# Altium schematic sheet C-03 eMMC_NAND_TF_QSPI.SchDoc("C-03 eMMC_NAND_TF_QSPI")
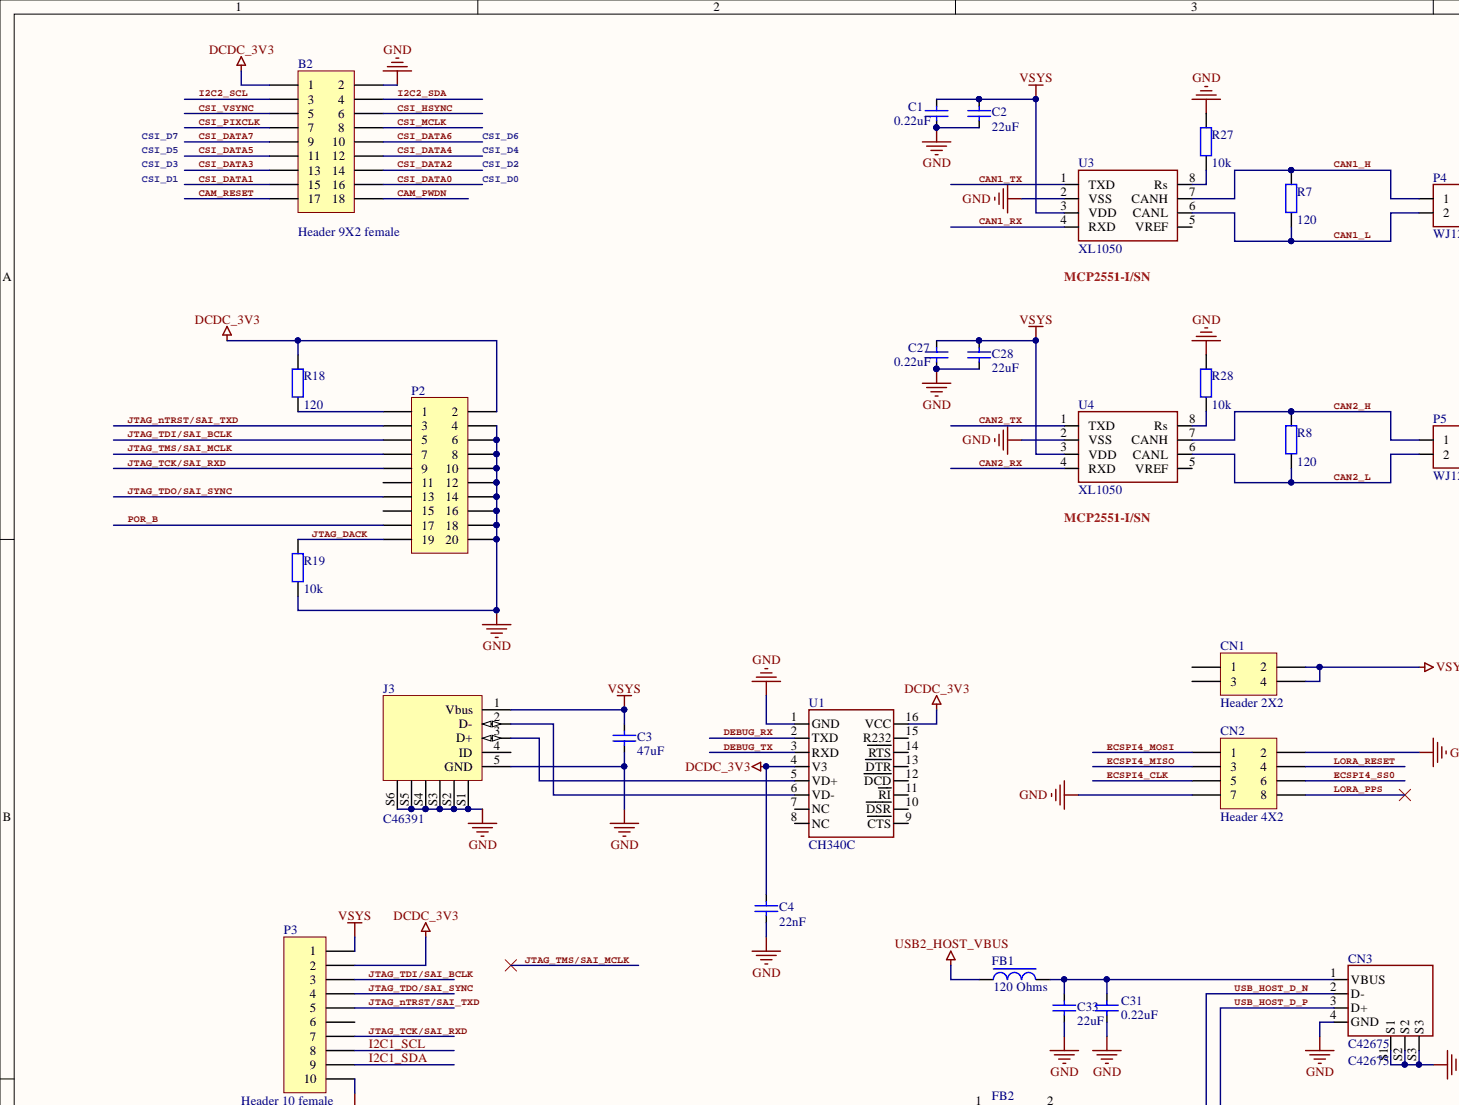
[diagram p0: C-03 eMMC_NAND_TF_QSPI.SchDoc, top left]
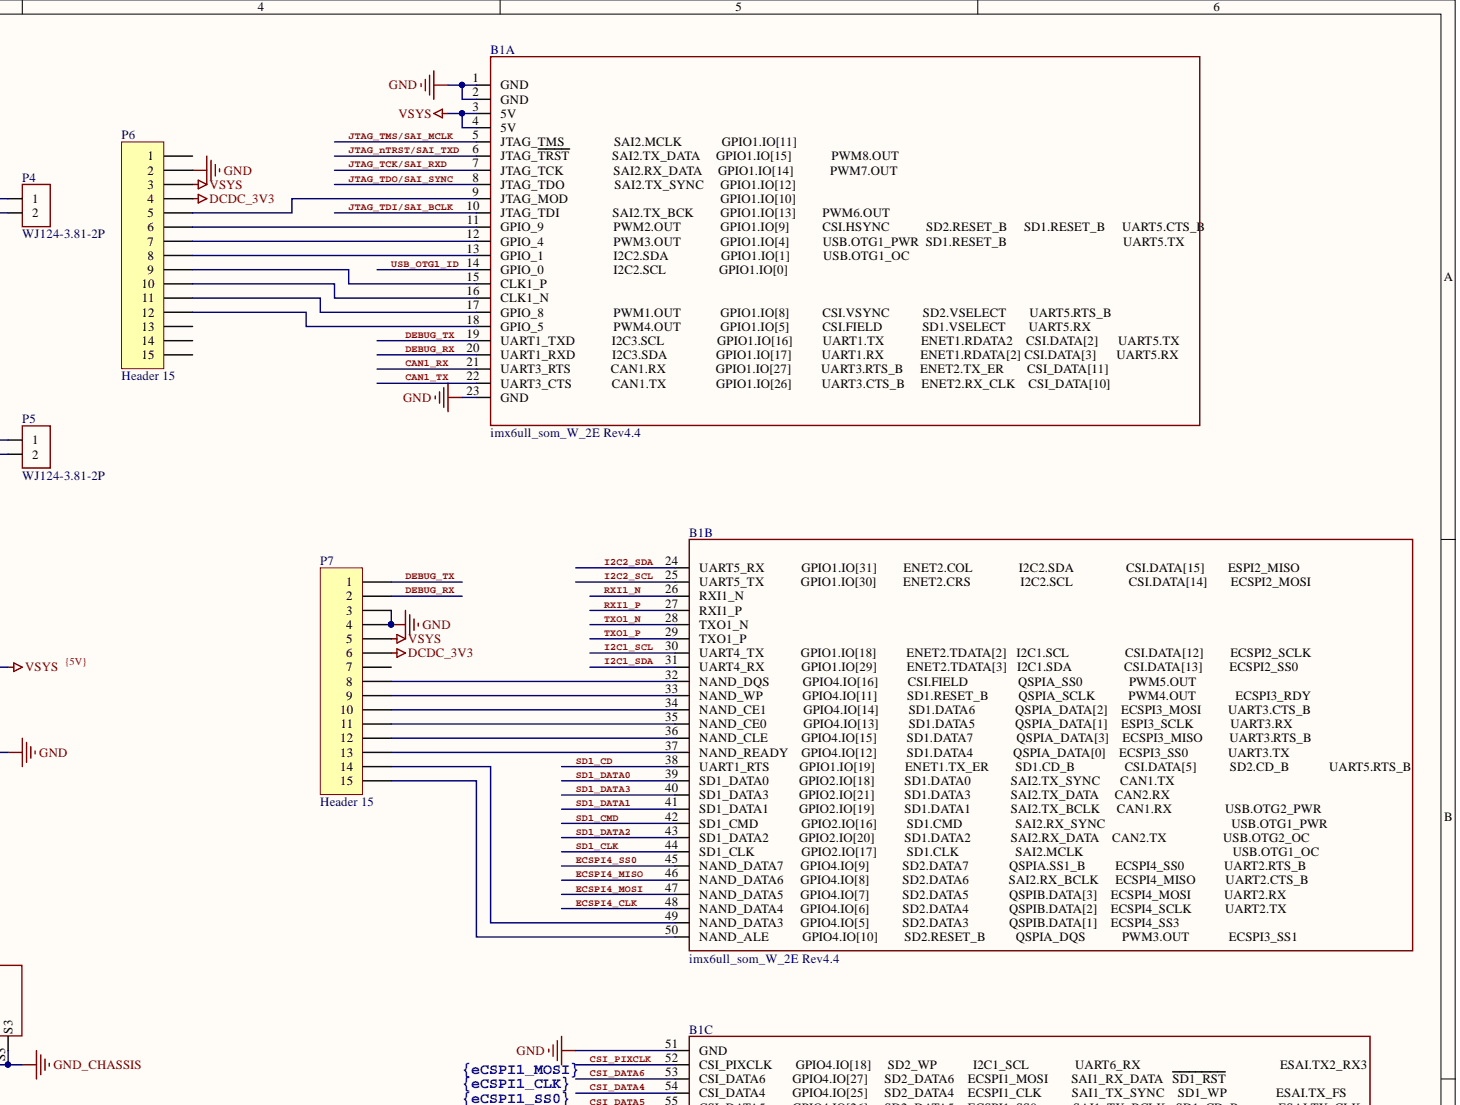
[diagram p1: C-03 eMMC_NAND_TF_QSPI.SchDoc, top right]
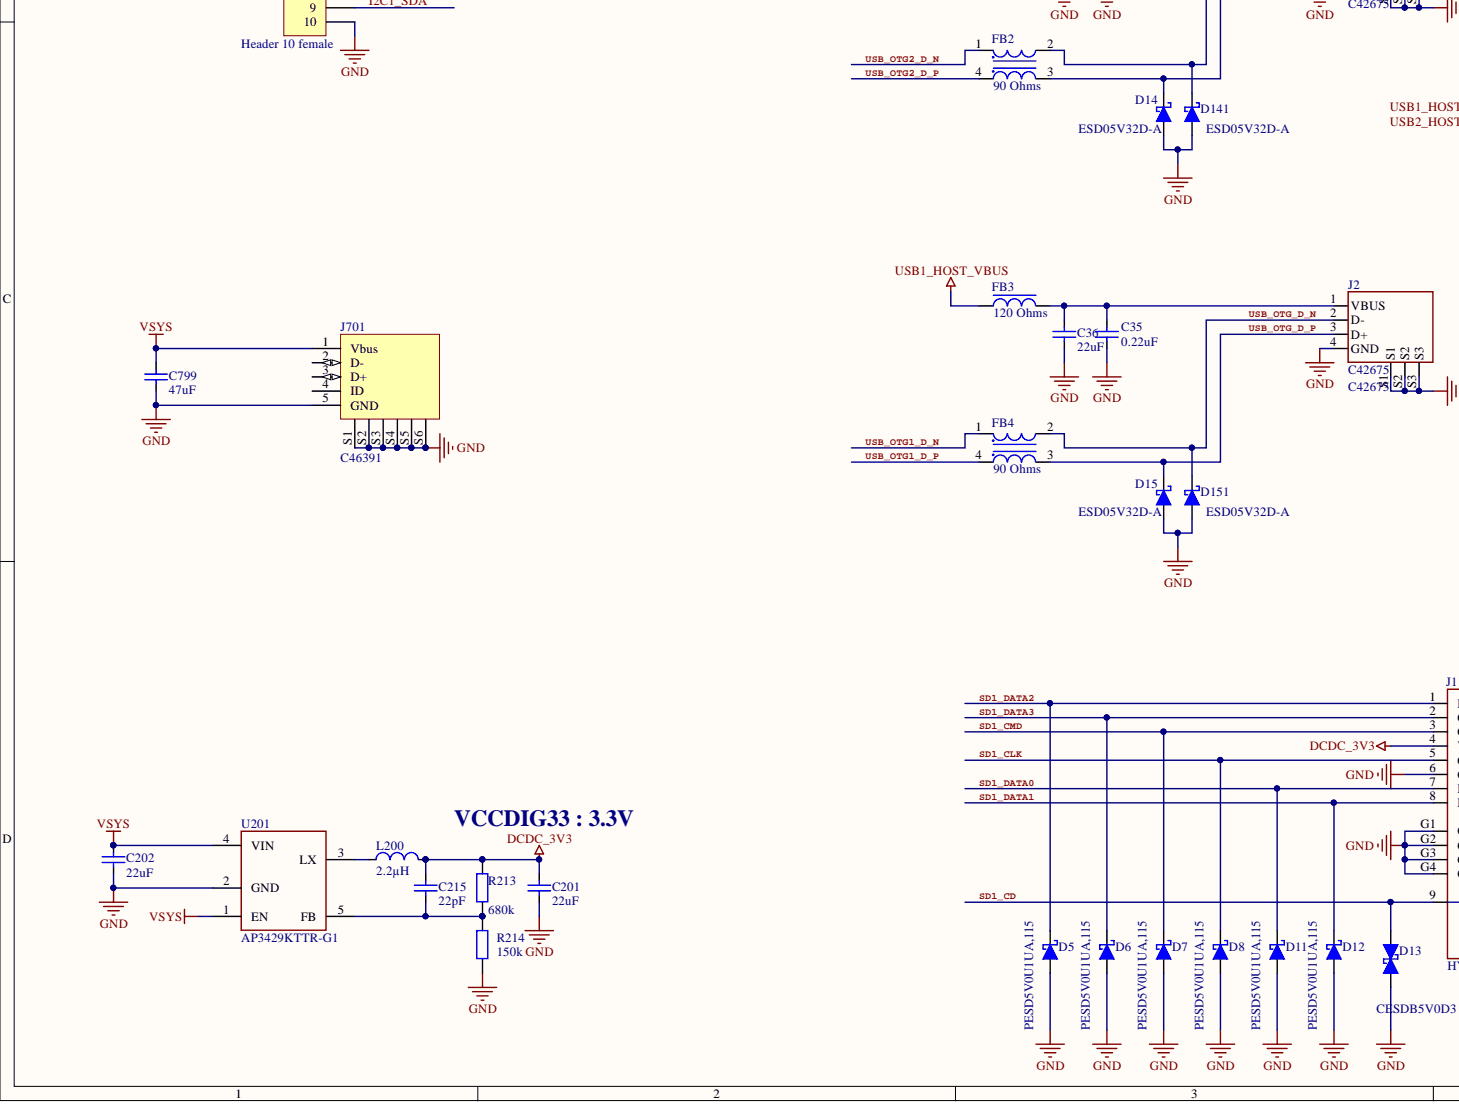
[diagram p2: C-03 eMMC_NAND_TF_QSPI.SchDoc, bottom left]
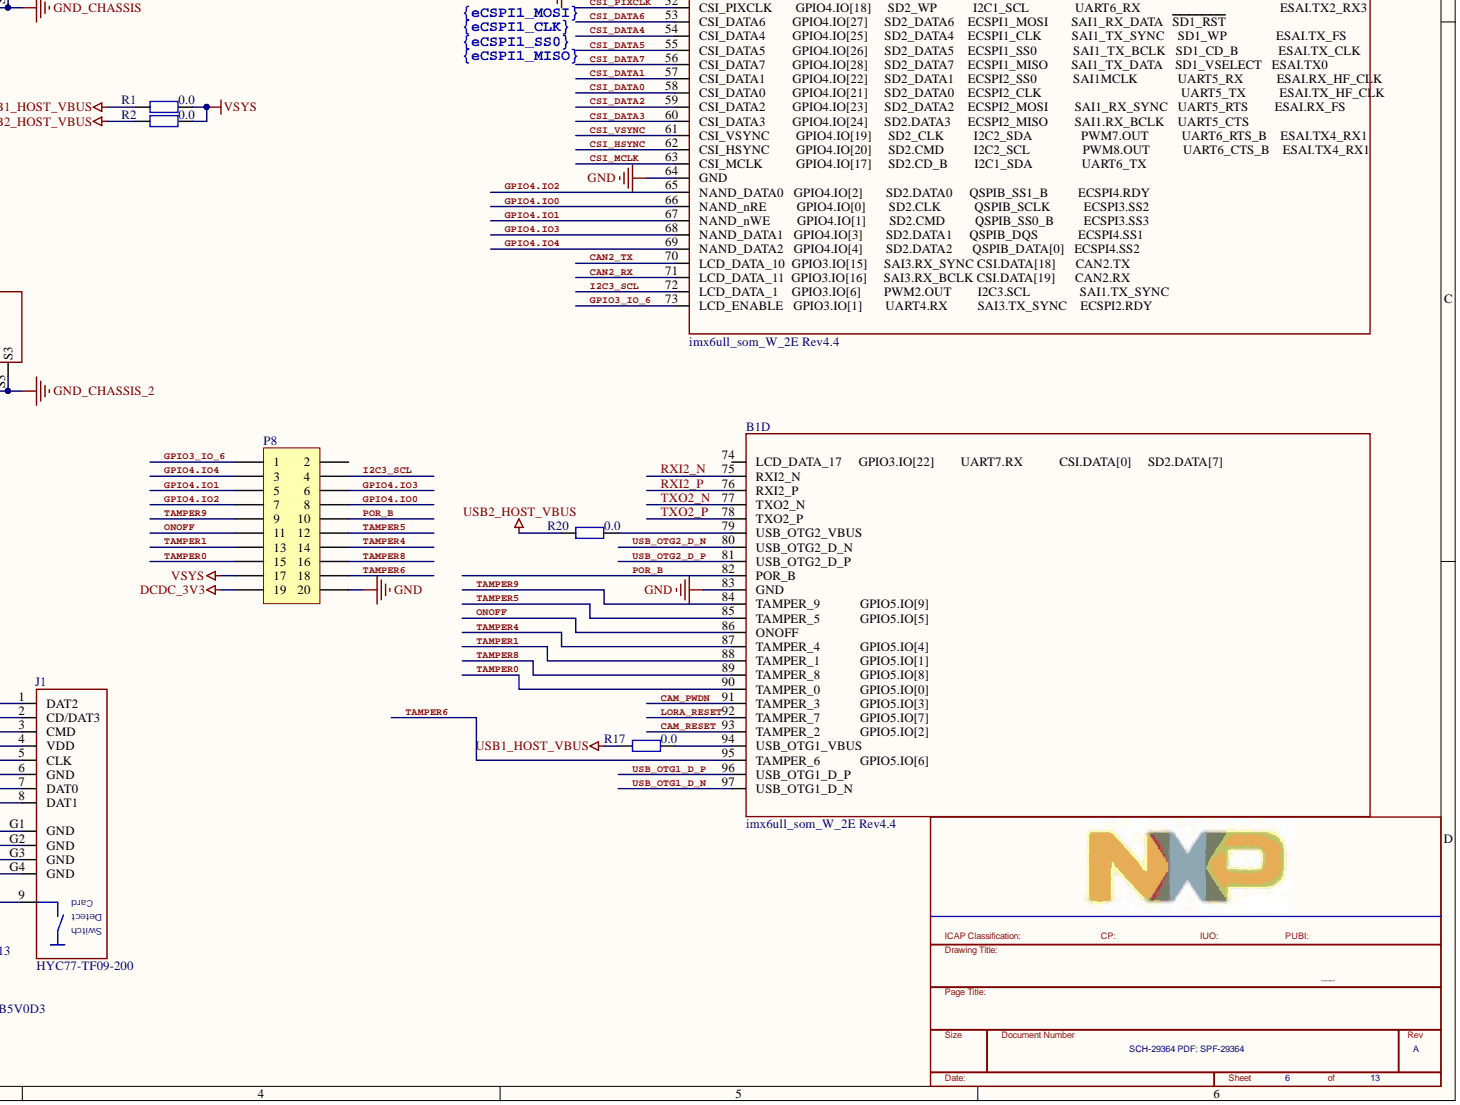
[diagram p3: C-03 eMMC_NAND_TF_QSPI.SchDoc, bottom right]

## Parts
B1  imx6ull_som_W_2E Rev4.4  Component Name: imx6ull_som_W_2E  [imx6ull_som]  (parts A,B,C,D)
B2  Header 9X2 female  Component Name: Header 9X2  [HDR2X9]
C1  value 0.22uF  [CAP0603R]  (hidden comment: CL10B224KA8NNNC)
C2  value 22uF  [CAP1206R]  (hidden comment: CC1206KKX5R6BB226)
C3  value 47uF  [CAP1206R]  (hidden comment: CL31A476MPHNNNE)
C4  value 22nF  [CAP0603R]  (hidden comment: CC0603KRX7R9BB223)
C27  value 0.22uF  [CAP0603R]  (hidden comment: CL10B224KA8NNNC)
C28  value 22uF  [CAP1206R]  (hidden comment: CC1206KKX5R6BB226)
C31  value 0.22uF  [CAP0603R]  (hidden comment: CL10B224KA8NNNC)
C33  value 22uF  [CAP1206R]  (hidden comment: CC1206KKX5R6BB226)
C35  value 0.22uF  [CAP0603R]  (hidden comment: CL10B224KA8NNNC)
C36  value 22uF  [CAP1206R]  (hidden comment: CC1206KKX5R6BB226)
C201  value 22uF  [CAP1206R]  (hidden comment: CC1206KKX5R6BB226)
C202  value 22uF  [CAP1206R]  (hidden comment: CC1206KKX5R6BB226)
C215  value 22pF  [CAP0603R]  (hidden comment: CC0603JRNPO9BN220)
C799  value 47uF  [CAP1206R]  (hidden comment: CL31A476MPHNNNE)
CN1  Header 2X2  [HDR2X2]
CN2  Header 4X2  [HDR2X4]
CN3  C42675  [C2345-Footprint-1]
D5  PESD5V0U1UA,115  [SOD-323]
D6  PESD5V0U1UA,115  [SOD-323]
D7  PESD5V0U1UA,115  [SOD-323]
D8  PESD5V0U1UA,115  [SOD-323]
D11  PESD5V0U1UA,115  [SOD-323]
D12  PESD5V0U1UA,115  [SOD-323]
D13  CESDB5V0D3  [SOD-323]
D14  ESD05V32D-A  [SOD-323]
D15  ESD05V32D-A  [SOD-323]
D141  ESD05V32D-A  [SOD-323]
D151  ESD05V32D-A  [SOD-323]
FB1  Impedance: 120 Ohms  [0603]  (hidden comment: PZ1608U121-2R0TF)
FB2  Impedance: 90 Ohms  [DLW21HN900SQ2L-Footprint-1]  (hidden comment: DLW21HN900SQ2L)
FB3  Impedance: 120 Ohms  [0603]  (hidden comment: PZ1608U121-2R0TF)
FB4  Impedance: 90 Ohms  [DLW21HN900SQ2L-Footprint-1]  (hidden comment: DLW21HN900SQ2L)
J1  HYC77-TF09-200  [0473521001]
J2  C42675  [C2345-Footprint-1]
J3  C46391  [10118192]
J701  C46391  [10118192]
L200  value 2.2µH  [LQH3225]  (hidden comment: LQH32PN2R2NNCL)
P2  CMP-013-0009-2  [HDR2X10]  (value not drawn)
P3  Header 10 female  Component Name: Header 10  [HDR1X10]
P4  WJ124-3.81-2P  [SCREW1X2_3.81mm_pitch]
P5  WJ124-3.81-2P  [SCREW1X2_3.81mm_pitch]
P6  Header 15  [HDR1X15]
P7  Header 15  [HDR1X15]
P8  CMP-013-0009-2  [HDR2X10]  (value not drawn)
R1  value 0.0  [0603]  (hidden comment: CMP-022-0120-1)
R2  value 0.0  [0603]  (hidden comment: CMP-022-0120-1)
R7  value 120  [0603]  (hidden comment: 0603WAF1200T5E)
R8  value 120  [0603]  (hidden comment: 0603WAF1200T5E)
R17  value 0.0  [0603]  (hidden comment: RC0603JR-070RL)
R18  value 120  [0603]  (hidden comment: 0603WAF1200T5E)
R19  value 10k  [0603]  (hidden comment: 0603WAF1002T5E)
R20  value 0.0  [0603]  (hidden comment: CMP-022-0120-1)
R27  value 10k  [0603]  (hidden comment: 0603WAF1002T5E)
R28  value 10k  [0603]  (hidden comment: 0603WAF1002T5E)
R213  value 680k  [0603]  (hidden comment: 0603WAF6803T5E)
R214  value 150k  [0603]  (hidden comment: 0603WAF1503T5E)
U1  CH340C  [SOIC-16_N]
U3  XL1050  [SOIC-8_N]
U4  XL1050  [SOIC-8_N]
U201  AP3429KTTR-G1  [TSOT-23-5]

## Nets
DCDC_3V3: B2.1 C4.2 C201.1 C215.2 J1.4(VDD) L200.2 P2.2 P3.2 P6.4 P7.6 P8.19 R18.2 R213.2 U1.4(V3) U1.16(VCC)
GND: B1.1(GND) B1.2(GND) B1.23(GND) B1.51(GND) B1.64(GND) B1.83(GND) B2.2 C1.1 C2.2 C3.1 C4.1 C27.1 C28.2 C31.1 C33.2 C35.1 C36.2 C201.2 C202.2 C799.1 CN2.2 CN2.7 CN3.4(GND) D5.2 D6.2 D7.2 D8.2 D11.2 D12.2 D13.2 D14.2 D15.2 D141.2 D151.2 J1.6(GND) J1.G1(GND) J1.G2(GND) J1.G3(GND) J1.G4(GND) J2.4(GND) J3.5(GND) J3.S1 J3.S2 J3.S3 J3.S4 J3.S5 J3.S6 J701.5(GND) J701.S1 J701.S2 J701.S3 J701.S4 J701.S5 J701.S6 P2.4 P2.6 P2.8 P2.10 P2.12 P2.14 P2.16 P2.18 P2.20 P3.10 P6.2 P7.3 P7.4 P8.20 R19.1 R27.2 R28.2 R214.1 U1.1(GND) U3.2(VSS) U4.2(VSS) U201.2(GND)
GND_CHASSIS: CN3.S1 CN3.S2 CN3.S3
GND_CHASSIS_2: J2.S1 J2.S2 J2.S3
USB1_HOST_VBUS: FB3.2 R1.1 R17.1
USB2_HOST_VBUS: FB1.2 R2.1 R20.1
VSYS: B1.3(5V) B1.4(5V) C1.2 C2.1 C3.2 C27.2 C28.1 C202.1 C799.2 CN1.2 CN1.4 J3.1(Vbus) J701.1(Vbus) P3.1 P6.3 P7.5 P8.17 R1.2 R2.2 U3.3(VDD) U4.3(VDD) U201.1(EN) U201.4(VIN)
CAM_PWDN: B1.91(TAMPER_3) B2.18
CAM_RESET: B1.93(TAMPER_2) B2.17
CAN1_H: P4.1 R7.2 U3.7(CANH)
CAN1_L: P4.2 R7.1 U3.6(CANL)
CAN1_RX: B1.21(UART3_RTS) U3.4(RXD)
CAN1_TX: B1.22(UART3_CTS) U3.1(TXD)
CAN2_H: P5.1 R8.2 U4.7(CANH)
CAN2_L: P5.2 R8.1 U4.6(CANL)
CAN2_RX: B1.71(LCD_DATA_11) U4.4(RXD)
CAN2_TX: B1.70(LCD_DATA_10) U4.1(TXD)
CSI_DATA0: B1.58(CSI_DATA0) B2.16
CSI_DATA1: B1.57(CSI_DATA1) B2.15
CSI_DATA2: B1.59(CSI_DATA2) B2.14
CSI_DATA3: B1.60(CSI_DATA3) B2.13
CSI_DATA4: B1.54(CSI_DATA4) B2.12
CSI_DATA5: B1.55(CSI_DATA5) B2.11
CSI_DATA6: B1.53(CSI_DATA6) B2.10
CSI_DATA7: B1.56(CSI_DATA7) B2.9
CSI_HSYNC: B1.62(CSI_HSYNC) B2.6
CSI_MCLK: B1.63(CSI_MCLK) B2.8
CSI_PIXCLK: B1.52(CSI_PIXCLK) B2.7
CSI_VSYNC: B1.61(CSI_VSYNC) B2.5
DEBUG_RX: B1.20(UART1_RXD) P7.2 U1.2(TXD)
DEBUG_TX: B1.19(UART1_TXD) P7.1 U1.3(RXD)
ECSPI4_CLK: B1.48(NAND_DATA4) CN2.5
ECSPI4_MISO: B1.46(NAND_DATA6) CN2.3
ECSPI4_MOSI: B1.47(NAND_DATA5) CN2.1
ECSPI4_SS0: B1.45(NAND_DATA7) CN2.6
GPIO3_IO_6: B1.73(LCD_ENABLE) P8.1
GPIO4.IO0: B1.66(NAND_nRE) P8.8
GPIO4.IO1: B1.67(NAND_nWE) P8.5
GPIO4.IO2: B1.65(NAND_DATA0) P8.7
GPIO4.IO3: B1.68(NAND_DATA1) P8.6
GPIO4.IO4: B1.69(NAND_DATA2) P8.3
I2C1_SCL: B1.30(UART4_TX) P3.8
I2C1_SDA: B1.31(UART4_RX) P3.9
I2C2_SCL: B1.25(UART5_TX) B2.3
I2C2_SDA: B1.24(UART5_RX) B2.4
I2C3_SCL: B1.72(LCD_DATA_1) P8.4
JTAG_DACK: P2.19 R19.2
JTAG_nTRST/SAI_TXD: B1.6(JTAG_TRST) P2.3 P3.5
JTAG_TCK/SAI_RXD: B1.7(JTAG_TCK) P2.9 P3.7
JTAG_TDI/SAI_BCLK: B1.10(JTAG_TDI) P2.5 P3.3
JTAG_TDO/SAI_SYNC: B1.8(JTAG_TDO) P2.13 P3.4
JTAG_TMS/SAI_MCLK: B1.5(JTAG_TMS) P2.7
LORA_PPS: CN2.8
LORA_RESET: B1.92(TAMPER_7) CN2.4
ONOFF: B1.86(ONOFF) P8.11
POR_B: B1.82(POR_B) P2.17 P8.10
RXI1_N: B1.26(RXI1_N)
RXI1_P: B1.27(RXI1_P)
RXI2_N: B1.75(RXI2_N)
RXI2_P: B1.76(RXI2_P)
SD1_CD: B1.38(UART1_RTS) D13.1 J1.9
SD1_CLK: B1.44(SD1_CLK) D8.1 J1.5(CLK)
SD1_CMD: B1.42(SD1_CMD) D7.1 J1.3(CMD)
SD1_DATA0: B1.39(SD1_DATA0) D11.1 J1.7(DAT0)
SD1_DATA1: B1.41(SD1_DATA1) D12.1 J1.8(DAT1)
SD1_DATA2: B1.43(SD1_DATA2) D5.1 J1.1(DAT2)
SD1_DATA3: B1.40(SD1_DATA3) D6.1 J1.2(CD/DAT3)
TAMPER0: B1.90(TAMPER_0) P8.15
TAMPER1: B1.88(TAMPER_1) P8.13
TAMPER4: B1.87(TAMPER_4) P8.14
TAMPER5: B1.85(TAMPER_5) P8.12
TAMPER6: B1.95(TAMPER_6) P8.18
TAMPER8: B1.89(TAMPER_8) P8.16
TAMPER9: B1.84(TAMPER_9) P8.9
TXO1_N: B1.28(TXO1_N)
TXO1_P: B1.29(TXO1_P)
TXO2_N: B1.77(TXO2_N)
TXO2_P: B1.78(TXO2_P)
USB_HOST_D_N: CN3.2(D-) D141.1 FB2.2
USB_HOST_D_P: CN3.3(D+) D14.1 FB2.3
USB_OTG1_D_N: B1.97(USB_OTG1_D_N) FB4.1
USB_OTG1_D_P: B1.96(USB_OTG1_D_P) FB4.4
USB_OTG1_ID: B1.14(GPIO_0)
USB_OTG2_D_N: B1.80(USB_OTG2_D_N) FB2.1
USB_OTG2_D_P: B1.81(USB_OTG2_D_P) FB2.4
USB_OTG_D_N: D151.1 FB4.2 J2.2(D-)
USB_OTG_D_P: D15.1 FB4.3 J2.3(D+)
NetB1_9: B1.9(JTAG_MOD) P6.5
NetB1_11: B1.11(GPIO_9) P6.6
NetB1_12: B1.12(GPIO_4) P6.7
NetB1_13: B1.13(GPIO_1) P6.8
NetB1_15: B1.15(CLK1_P) P6.9
NetB1_16: B1.16(CLK1_N) P6.10
NetB1_17: B1.17(GPIO_8) P6.11
NetB1_18: B1.18(GPIO_5) P6.12
NetB1_32: B1.32(NAND_DQS) P7.8
NetB1_33: B1.33(NAND_WP) P7.9
NetB1_34: B1.34(NAND_CE1) P7.10
NetB1_35: B1.35(NAND_CE0) P7.11
NetB1_36: B1.36(NAND_CLE) P7.12
NetB1_37: B1.37(NAND_READY) P7.13
NetB1_49: B1.49(NAND_DATA3) P7.14
NetB1_50: B1.50(NAND_ALE) P7.15
NetB1_79: B1.79(USB_OTG2_VBUS) R20.2
NetB1_94: B1.94(USB_OTG1_VBUS) R17.2
NetC31_2: C31.2 C33.1 CN3.1(VBUS) FB1.1
NetC35_2: C35.2 C36.1 FB3.1 J2.1(VBUS)
NetC215_1: C215.1 R213.1 R214.2 U201.5(FB)
NetJ3_2: J3.2(D-) U1.6(VD-)
NetJ3_3: J3.3(D+) U1.5(VD+)
NetL200_1: L200.1 U201.3(LX)
NetP2_1: P2.1 R18.1
NetR27_1: R27.1 U3.8(Rs)
NetR28_1: R28.1 U4.8(Rs)

Not connected: B1 74(LCD_DATA_17); CN1 1 3; J3 4(ID); J701 2(D-) 3(D+) 4(ID); P2 11 15; P3 6; P6 1 13 14 15; P7 7; P8 2; U1 7(NC) 8(NC) 9(CTS) 10(DSR) 11(RI) 12(DCD) 13(DTR) 14(RTS) 15(R232); U3 5(VREF); U4 5(VREF)

## Library data of the parts
B1 = CMP-00216-1: IMX6ULL SOM 2Eth + WiFi version Rev4.4; Manufacturer: m2m-tele.com; PriceQty: 1; Price: 12,0; Pin Count: 77; Library Name: <Company> Vault; Designator: imx6ull_som_W_2E; Component Kind: Standard
B2 = CMP-00205-1: Header, 9-Pin, Dual row; Part Number: C71956; Manufacturer: BOOMELE; Signal Integrity: Connector; PriceSupplier: https://lcsc.com/product-detail/Pin-Header-Female-Header_BOOMELE-Boom-Precision-Elec-C71956_C71956.html; PriceQty: 50; Price: 0,0585; Pin Count: 18; Library Name: <Company> Vault; HelpURL: https://lcsc.com/product-detail/Pin-Header-Female-Header_BOOMELE-Boom-Precision-Elec-C71956_C71956.html; Component Kind: Standard
C1 C27 C31 C35 = CMP-00194-1: CAP CER 0.1UF 50V ±10% X7R 0603; Manufacturer: Samsung; Voltage: 25V; Tolerance: ±10%; Temperature: -55°C ~ 125°C; Technology: X7R; Simulation: CAP; Signal Integrity: Cap; PriceSupplier: https://lcsc.com/product-detail/Multilayer-Ceramic-Capacitors-MLCC-SMD-SMT_SAMSUNG_CL10B224KA8NNNC_220nF-224-10-25V_C21120.html; PriceQty: 50; Price: 0,0074; Pin Count: 2; Part Number: CL10B224KA8NNNC; Library Name: <Company> Vault; HelpURL: https://lcsc.com/product-detail/Multilayer-Ceramic-Capacitors-MLCC-SMD-SMT_SAMSUNG_CL10B224KA8NNNC_220nF-224-10-25V_C21120.html; Designator: CL10B224KA8NNNC; Component Name: CL10B224KA8NNNC; Component Kind: Standard
C2 C28 C33 C36 = CMP-004-0058-1: CAP CER 22UF 10V ±10% X5R 1206; Manufacturer: Yageo; Voltage: 10V; Tolerance: ±10%; Temperature: -55°C ~ 125°C; Technology: X5R; Simulation: CAP; Signal Integrity: Cap; PriceSupplier: https://lcsc.com/product-detail/Multilayer-Ceramic-Capacitors-MLCC-SMD-SMT_22uF-226-10-10V_C110046.html; PriceQty: 100; Price: 0,0378; Pin Count: 2; Part Number: CC1206KKX5R6BB226; Library Name: <Company> Vault; HelpURL: https://www.digikey.com/product-detail/en/yageo/CC1206KKX5R6BB226/311-1939-1-ND/5195841; Component Name: CC1206KKX5R6BB226; Component Kind: Standard
C3 C799 = CMP-00176-1: CAP CER 47UF 10V ±10% X5R 1206; Manufacturer: Yageo; Tolerance: ±20%; Voltage: 10V; Temperature: -55°C ~ 85°C; Technology: X5R; Simulation: CAP; Signal Integrity: Cap; PriceSupplier: https://lcsc.com/product-detail/Multilayer-Ceramic-Capacitors-MLCC-SMD-SMT_SAMSUNG_CL31A476MPHNNNE_47uF-476-20-10V_C96123.html/?href=jlc-SMT; PriceQty: 1; Price: 0,0988; Pin Count: 2; Part Number: CL31A476MPHNNNE; Library Name: <Company> Vault; HelpURL: https://lcsc.com/product-detail/Multilayer-Ceramic-Capacitors-MLCC-SMD-SMT_SAMSUNG_CL31A476MPHNNNE_47uF-476-20-10V_C96123.html/?href=jlc-SMT; Designator: CL31A476MPHNNNE; Component Name: CL31A476MPHNNNE; Component Kind: Standard
C4 = CMP-004-0047-1: CAP CER 0.022UF 50V ±10% X7R 0603; Manufacturer: Yageo; Voltage: 50V; Tolerance: ±10%; Temperature: -55°C ~ 125°C; Technology: X7R; Simulation: CAP; Signal Integrity: Cap; PriceSupplier: https://www.promelec.ru/product/148489/; PriceQty: 1; Price: 0,021; Pin Count: 2; Part Number: CC0603KRX7R9BB223; Library Name: <Company> Vault; HelpURL: https://www.digikey.com/product-detail/en/yageo/CC0603KRX7R9BB223/311-1370-1-ND/2103154; Designator: CC0603KRX7R9BB223; Component Name: CC0603KRX7R9BB223; Component Kind: Standard
C201 C202 = CC1206KKX5R6BB226: CAP CER 22UF 10V ±10% X5R 1206; Manufacturer: Yageo; Voltage: 10V; Tolerance: ±10%; Temperature: -55°C ~ 125°C; Technology: X5R; Simulation: CAP; Signal Integrity: Cap; PriceSupplier: https://lcsc.com/product-detail/Multilayer-Ceramic-Capacitors-MLCC-SMD-SMT_22uF-226-10-10V_C110046.html; PriceQty: 100; Price: 0,0378; Part Number: CC1206KKX5R6BB226; Library Name: capacitor.IntLib; HelpURL: https://www.digikey.com/product-detail/en/yageo/CC1206KKX5R6BB226/311-1939-1-ND/5195841; Component Kind: Standard
C215 = CMP-00198-1: CAP CER 22PF 50V ±1% NPO 0603; Manufacturer: Yageo; Voltage: 50V; Tolerance: ±1%; Temperature: -55°C ~ 125°C; Technology: C0G, NP0; Simulation: CAP; Signal Integrity: Cap; PriceSupplier: https://lcsc.com/product-detail/Multilayer-Ceramic-Capacitors-MLCC-SMD-SMT_YAGEO-CC0603JRNPO9BN220_C105620.html; PriceQty: 100; Price: 0,0033; Pin Count: 2; Part Number: CC0603JRNPO9BN220; Library Name: <Company> Vault; HelpURL: https://www.digikey.com/en/products/detail/yageo/CC0603JRNPO9BN220/302796; Designator: CC0603JRNPO9BN220; Component Name: CC0603JRNPO9BN220; Component Kind: Standard
CN1 = CMP-013-0038-2: Header, 2-Pin, Dual row; Part Number: 210-72-02GB01; Manufacturer: PINREX; Temperature: -40 to 105C; Signal Integrity: Connector; PriceSupplier: https://lcsc.com/product-detail/Pin-Header-Female-Header_PINREX-210-72-02GB01_C402780.html; PriceQty: 50; Price: 0,0137; Pin Count: 4; Library Name: <Company> Vault; HelpURL: https://lcsc.com/product-detail/Pin-Header-Female-Header_PINREX-210-72-02GB01_C402780.html; Component Name: Header 2X2; Component Kind: Standard
CN2 = CMP-013-0046-2: Header, 4-Pin, Dual row; Part Number: MTP125-1204S1; Temperature: -40 to 105C; Signal Integrity: Connector; PriceSupplier: https://lcsc.com/product-detail/Pin-Header-Female-Header_MINTRON-MTP125-1204S1_C358693.html; PriceQty: 20; Price: 0,0329; Pin Count: 8; Library Name: <Company> Vault; HelpURL: https://lcsc.com/product-detail/Pin-Header-Female-Header_MINTRON-MTP125-1204S1_C358693.html; Designator: Header 4X2; Component Name: Header 4X2; Component Kind: Standard
CN3 J2 = CMP-00188-2: USB - Type A Female USB 2 4 Through Hole USB Connector; Manufacturer: Jing Extension of the Electronic Co; Temperature: -35°C ~ 85°C; PriceSupplier: https://lcsc.com/product-detail/USB-Connectors_Jing-Extension-of-the-Electronic-Co-A-F9013-65O-Vinyl-Not-high-temperature_C42675.html; PriceQty: 100; Price: 0,0412; Pin Count: 4; Part Number: C42675; Library Name: <Company> Vault; HelpURL: https://lcsc.com/product-detail/USB-Connectors_Jing-Extension-of-the-Electronic-Co-A-F9013-65O-Vinyl-Not-high-temperature_C42675.html; Component Name: C42675; Component Kind: Standard
D5-D8 D11 D12 = CMP-00195-1: TVS DIODE 5V SOD323; Manufacturer: Diodes Incorporated; Temperature: -55°C ~ 150°C (TA); PriceSupplier: https://lcsc.com/product-detail/Diodes-ESD_Nexperia-PESD5V0U1UA-115_C139614.html; PriceQty: 50; Price: 0,0528; Pin Count: 2; Part Number: PESD5V0U1UA,115; Library Name: <Company> Vault; HelpURL: https://www.digikey.com/en/products/detail/nexperia-usa-inc/PESD5V0U1UA115/1992975; Designator: PESD5V0U1UA,115; Component Name: PESD5V0U1UA,115; Component Kind: Standard
D13 = CMP-00196-2: Bidirectional 5V (Max) 5.8V SOD-323 Diode; Manufacturer: Changjiang Electronics Tech; Temperature: -55°C ~ 150°C (TA); PriceSupplier: https://lcsc.com/product-detail/Diodes-ESD_Changjiang-Electronics-Tech-CJ-CESDB5V0D3_C154987.html; PriceQty: 20; Price: 0,0253; Pin Count: 2; Part Number: CESDB5V0D3; Library Name: <Company> Vault; HelpURL: https://lcsc.com/product-detail/Diodes-ESD_Changjiang-Electronics-Tech-CJ-CESDB5V0D3_C154987.html; Component Name: CESDB5V0D3; Component Kind: Standard
D14 D15 D141 D151 = CMP-00204-1: TVS DIODE 5V SOD323; Manufacturer: SOCAY; Temperature: -55°C ~ 150°C (TA); PriceSupplier: https://lcsc.com/product-detail/TVS_SOCAY-Elec-ESD05V32D-A_C303800.html; PriceQty: 20; Price: 0,0514; Pin Count: 2; Part Number: ESD05V32D-A; Library Name: <Company> Vault; HelpURL: https://lcsc.com/product-detail/TVS_SOCAY-Elec-ESD05V32D-A_C303800.html; Designator: ESD05V32D-A; Component Name: ESD05V32D-A; Component Kind: Standard
FB1 FB3 = CMP-00148-1: FERRITE BEAD 120 OHM 0603 2A; Manufacturer: Sunlord; Value: 120 Ohms @ 100MHz; Temperature: -55°C ~ 125°C; PriceSupplier: https://lcsc.com/product-detail/Ferrite-Beads_Sunlord-PZ1608U121-2R0TF_C304464.html; PriceQty: 50; Price: 0,0048; Pin Count: 2; Part number: PZ1608U121-2R0TF; Library Name: <Company> Vault; HelpURL: https://lcsc.com/product-detail/Ferrite-Beads_Sunlord-PZ1608U121-2R0TF_C304464.html; Designator: PZ1608U121-2R0TF; Current: 2A; Component Name: PZ1608U121-2R0TF; Component Kind: Standard
FB2 FB4 = CMP-00150-1: CMC 330MA 2LN 90 OHM SMD; Manufacturer: Murata Electronics North America; Value: 90 Ohms @ 100MHz; Temperature: -40°C ~ 85°C; PriceSupplier: https://lcsc.com/product-detail/Common-Mode-Chokes-Filters_Murata-Electronics_DLW21HN900SQ2L-CUTTAPE_Murata-Electronics-DLW21HN900SQ2L-CUTTAPE_C80529.html; PriceQty: 50; Price: 0,04812; Pin Count: 2; Part number: DLW21HN900SQ2L; Library Name: <Company> Vault; HelpURL: https://www.digikey.com/product-detail/en/murata-electronics/DLW21HN900SQ2L/490-1064-1-ND/584510; Designator: DLW21HN900SQ2L; Current: 330mA; Component Name: DLW21HN900SQ2L; Component Kind: Standard
J1 = CMP-00146-1: CONN MICRO SD CARD PUSH-PUSH R/A; Manufacturer: Molex, LLC; PriceSupplier: https://lcsc.com/product-detail/Card-Sockets-Connectors_HOAUC-HYC77-TF09-200_C341092.html; PriceQty: 50; Price: 0,0783; Pin Count: 13; Part Number: HYC77-TF09-200; Library Name: <Company> Vault; HelpURL: https://lcsc.com/product-detail/Card-Sockets-Connectors_HOAUC-HYC77-TF09-200_C341092.html; Designator: HYC77-TF09-200; Component Name: HYC77-TF09-200; Component Kind: Standard
J3 J701 = CMP-00201-1: CONN USB MICRO B RECPT SMT R/A; Manufacturer: Jing Extension of the Electronic Co; Temperature: -30°C ~ 80°C; PriceSupplier: https://lcsc.com/product-detail/USB-Connectors_Jing-Extension-of-the-Electronic-Co-MINI-5PMotherboard-Copper-shell-with-column-LCPVinyl-Without-high-temperature-glue-High-temperature_C46391.html; PriceQty: 50; Price: 0,0561; Pin Count: 11; Part Number: C46391; Library Name: <Company> Vault; HelpURL: https://lcsc.com/product-detail/USB-Connectors_Jing-Extension-of-the-Electronic-Co-MINI-5PMotherboard-Copper-shell-with-column-LCPVinyl-Without-high-temperature-glue-High-temperature_C46391.html; Designator: C46391; Component Name: C46391; Component Kind: Standard
L200 = LQH32PN2R2NNCL: FIXED IND 2.2UH 1.85A 76.8 MOHM; Manufacturer: Murata Electronics North America; Tolerance: ±30%; Temperature: -40°C ~ 85°C; Simulation: INDUCTOR; Signal Integrity: Inductor; PriceSupplier: https://lcsc.com/product-detail/New-Arrivals_Murata-Electronics-LQH32PN2R2NNCL_C389569.html; PriceQty: 100; Price: 0,088; Part Number: LQH32PN2R2NNCL; Library Name: inductor.IntLib; HelpURL: https://www.digikey.com/product-detail/en/murata-electronics-north-america/LQH32PN2R2NNCL/490-12036-1-ND/5403111; Component Kind: Standard
P2 P8 = CMP-013-0009-2: Header, 10-Pin, Dual row; Part Number: MTP125-1210S1; Manufacturer: MINTRON; Component Name: Header 10X2; Temperature: -40 to 105C; Signal Integrity: Connector; PriceSupplier: https://lcsc.com/product-detail/Pin-Header-Female-Header_MINTRON-MTP125-1210S1_C358699.html; PriceQty: 50; Price: 0,06; Pin Count: 20; Library Name: <Company> Vault; HelpURL: https://lcsc.com/product-detail/Pin-Header-Female-Header_MINTRON-MTP125-1210S1_C358699.html; Component Kind: Standard
P3 = CMP-00206-1: Header, 10-Pin; Part Number: C35445; Manufacturer: BOOMELE; Temperature: -40 to 105C; Signal Integrity: Connector; PriceSupplier: https://lcsc.com/product-detail/Pin-Header-Female-Header_BOOMELE-Boom-Precision-Elec-C35445_C35445.html; PriceQty: 100; Price: 0,041; Pin Count: 10; Library Name: <Company> Vault; HelpURL: https://lcsc.com/product-detail/Pin-Header-Female-Header_BOOMELE-Boom-Precision-Elec-C35445_C35445.html; Component Kind: Standard
P4 P5 = CMP-00202-1: TERM BLK 2P SIDE ENT 3.81MM PCB; Manufacturer: Ningbo Kangnex Elec; Temperature: -40°C ~ 110°C; Signal Integrity: Connector; PriceSupplier: https://lcsc.com/product-detail/Screw-terminal_Ningbo-Kangnex-Elec-WJ124-3-81-2P_C69811.html; PriceQty: 100; Price: 0,0908; Pin Count: 2; Part Number: WJ124-3.81-2P; Library Name: <Company> Vault; HelpURL: https://lcsc.com/product-detail/Screw-terminal_Ningbo-Kangnex-Elec-WJ124-3-81-2P_C69811.html; Designator: WJ124-3.81-2P; Component Name: WJ124-3.81-2P; Component Kind: Standard
P6 P7 = CMP-013-0027-2: Header, 15-Pin; Part Number: C124373; Manufacturer: Ckmtw; Temperature: -40 to 105C; Signal Integrity: Connector; PriceSupplier: https://lcsc.com/product-detail/Pin-Header-Female-Header_Ckmtw-Shenzhen-Cankemeng-Headers-Pins-1-15P-2-54mm-Straight-line_C124373.html; PriceQty: 50; Price: 0,0572; Pin Count: 15; Library Name: <Company> Vault; HelpURL: https://lcsc.com/product-detail/Pin-Header-Female-Header_Ckmtw-Shenzhen-Cankemeng-Headers-Pins-1-15P-2-54mm-Straight-line_C124373.html; Component Name: Header 15; Component Kind: Standard
R1 R2 R20 = CMP-022-0120-1: RES SMD 0 OHM JUMPER 1/10W 0603; Part Number: RC0603JR-070RL; Manufacturer: Yageo; Temperature: -55°C ~ 155°C; Simulation: RESISTOR; Signal Integrity: Res; PriceSupplier: https://www.promelec.ru/product/22798/; PriceQty: 1; Price: 0,0060; Pin Count: 2; Library Name: <Company> Vault; HelpURL: https://www.digikey.com/product-detail/en/yageo/RC0603JR-070RL/311-0.0GRCT-ND/729622; Component Name: RC0603JR-070RL; Component Kind: Standard
R7 R8 R18 = CMP-00192-1: RES SMD 120 OHM 1% 1/10W 0603; Manufacturer: Uniroyal; Tolerance: ±1%; Temperature: -55°C ~ 155°C; Simulation: RESISTOR; Signal Integrity: Res; PriceSupplier: https://lcsc.com/product-detail/Chip-Resistor-Surface-Mount_Uniroyal-Elec-0603WAF1200T5E_C22787.html; PriceQty: 100; Price: 0,0018; Pin Count: 1; Part Number: 0603WAF1200T5E; Library Name: <Company> Vault; HelpURL: https://lcsc.com/product-detail/Chip-Resistor-Surface-Mount_Uniroyal-Elec-0603WAF1200T5E_C22787.html; Designator: 0603WAF1200T5E; Component Name: 0603WAF1200T5E; Component Kind: Standard
R17 = RC0603JR-070RL: RES SMD 0 OHM JUMPER 1/10W 0603; Manufacturer: Yageo; Temperature: -55°C ~ 155°C; Simulation: RESISTOR; Signal Integrity: Res; PriceSupplier: https://www.promelec.ru/product/22798/; PriceQty: 1; Price: 0,0060; Part Number: RC0603JR-070RL; Library Name: resistor.IntLib; HelpURL: https://www.digikey.com/product-detail/en/yageo/RC0603JR-070RL/311-0.0GRCT-ND/729622; Component Kind: Standard
R19 R27 R28 = CMP-00193-1: RES SMD 10K OHM 1% 1/10W 0603; Manufacturer: Yageo; Tolerance: ±1%; Temperature: -55°C ~ 155°C; Simulation: RESISTOR; Signal Integrity: Res; PriceSupplier: https://lcsc.com/product-detail/Chip-Resistor-Surface-Mount_Uniroyal-Elec-0603WAF1002T5E_C25804.html; PriceQty: 100; Price: 0,0009; Pin Count: 2; Part Number: 0603WAF1002T5E; Library Name: <Company> Vault; HelpURL: https://lcsc.com/product-detail/Chip-Resistor-Surface-Mount_Uniroyal-Elec-0603WAF1002T5E_C25804.html; Designator: 0603WAF1002T5E; Component Name: 0603WAF1002T5E; Component Kind: Standard
R213 = CMP-00199-1: RES SMD 680k 1% 1/10W 0603; Manufacturer: Uniroyal; Tolerance: 1%; Temperature: -55°C ~ 155°C; Simulation: RESISTOR; Signal Integrity: Res; PriceSupplier: https://lcsc.com/product-detail/Chip-Resistor-Surface-Mount_UNI-ROYAL-Uniroyal-Elec-0603WAF6803T5E_C25822.html; PriceQty: 100; Price: 0,0012; Pin Count: 2; Part Number: 0603WAF6803T5E; Library Name: <Company> Vault; HelpURL: https://lcsc.com/product-detail/Chip-Resistor-Surface-Mount_UNI-ROYAL-Uniroyal-Elec-0603WAF6803T5E_C25822.html; Designator: 0603WAF6803T5E; Component Name: 0603WAF6803T5E; Component Kind: Standard
R214 = CMP-00200-1: RES SMD 150k 1% 1/10W 0603; Manufacturer: Uniroyal; Tolerance: 1%; Temperature: -55°C ~ 155°C; Simulation: RESISTOR; Signal Integrity: Res; PriceSupplier: https://lcsc.com/product-detail/Chip-Resistor-Surface-Mount_UNI-ROYAL-Uniroyal-Elec-0603WAF1503T5E_C22807.html; PriceQty: 100; Price: 0,0012; Pin Count: 2; Part Number: 0603WAF1503T5E; Library Name: <Company> Vault; HelpURL: https://lcsc.com/product-detail/Chip-Resistor-Surface-Mount_UNI-ROYAL-Uniroyal-Elec-0603WAF1503T5E_C22807.html; Designator: 0603WAF1503T5E; Component Name: 0603WAF1503T5E; Component Kind: Standard
U1 = CMP-00153-1: Transceiver USB 2.0 2Mbps SOP-16_150mil; Manufacturer: WCH(Jiangsu Qin Heng); Temperature: -40°C ~ 80°C; PriceSupplier: https://lcsc.com/product-detail/USB-ICs_WCH-Jiangsu-Qin-Heng-CH340C_C84681.html; PriceQty: 100; Price: 0,28; Pin Count: 16; Part Number: CH340C; Library Name: <Company> Vault; HelpURL: https://lcsc.com/product-detail/USB-ICs_WCH-Jiangsu-Qin-Heng-CH340C_C84681.html; Designator: CH340C; Component Name: CH340C; Component Kind: Standard
U3 U4 = CMP-00203-1: IC TRANSCEIVER HALF 1/1 8SOIC; Manufacturer: XINLUDA; Temperature: -40°C ~ 150°C; PriceSupplier: https://lcsc.com/product-detail/CAN-ICs_XINLUDA-XL1050_C609746.html; PriceQty: 100; Price: 0,1977; Pin Count: 8; Part Number: XL1050; Library Name: <Company> Vault; HelpURL: https://lcsc.com/product-detail/CAN-ICs_XINLUDA-XL1050_C609746.html; Designator: XL1050; Component Name: XL1050; Component Kind: Standard
U201 = CMP-014-0017-3: IC REG BUCK ADJ 2A SYNC TSOT25; Manufacturer: Diodes Incorporated; MarkingCode: L2F; Temperature: -40°C ~ 85°C (TA); PriceSupplier: https://lcsc.com/product-detail/DC-DC-Converters_Diodes-Incorporated-AP3429KTTR-G1_C507879.html; PriceQty: 50; Price: 0,0804; Pin Count: 5; Part Number: AP3429KTTR-G1; Library Name: <Company> Vault; HelpURL: https://www.digikey.com/product-detail/en/diodes-incorporated/AP3429KTTR-G1/AP3429KTTR-G1DIDKR-ND/5359847; Component Name: AP3429KTTR-G1; Component Kind: Standard
